# Altium schematic sheet POWER.SchDoc
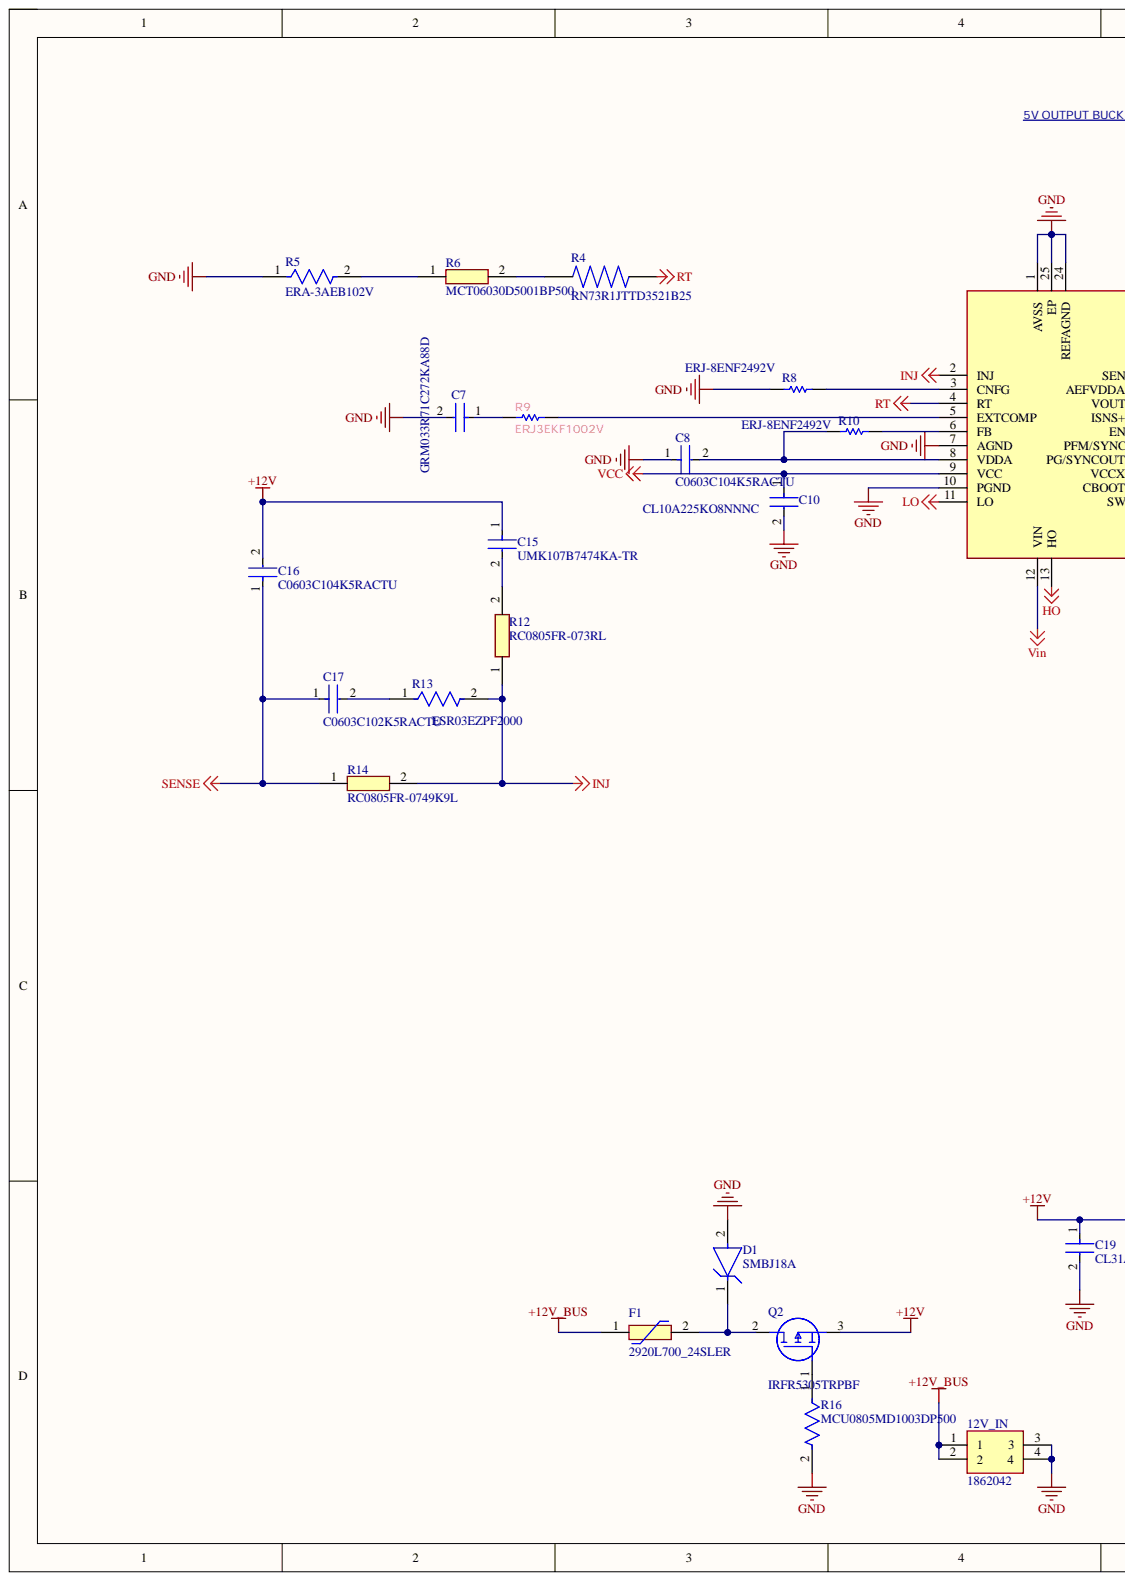
[diagram p0: POWER.SchDoc, left]
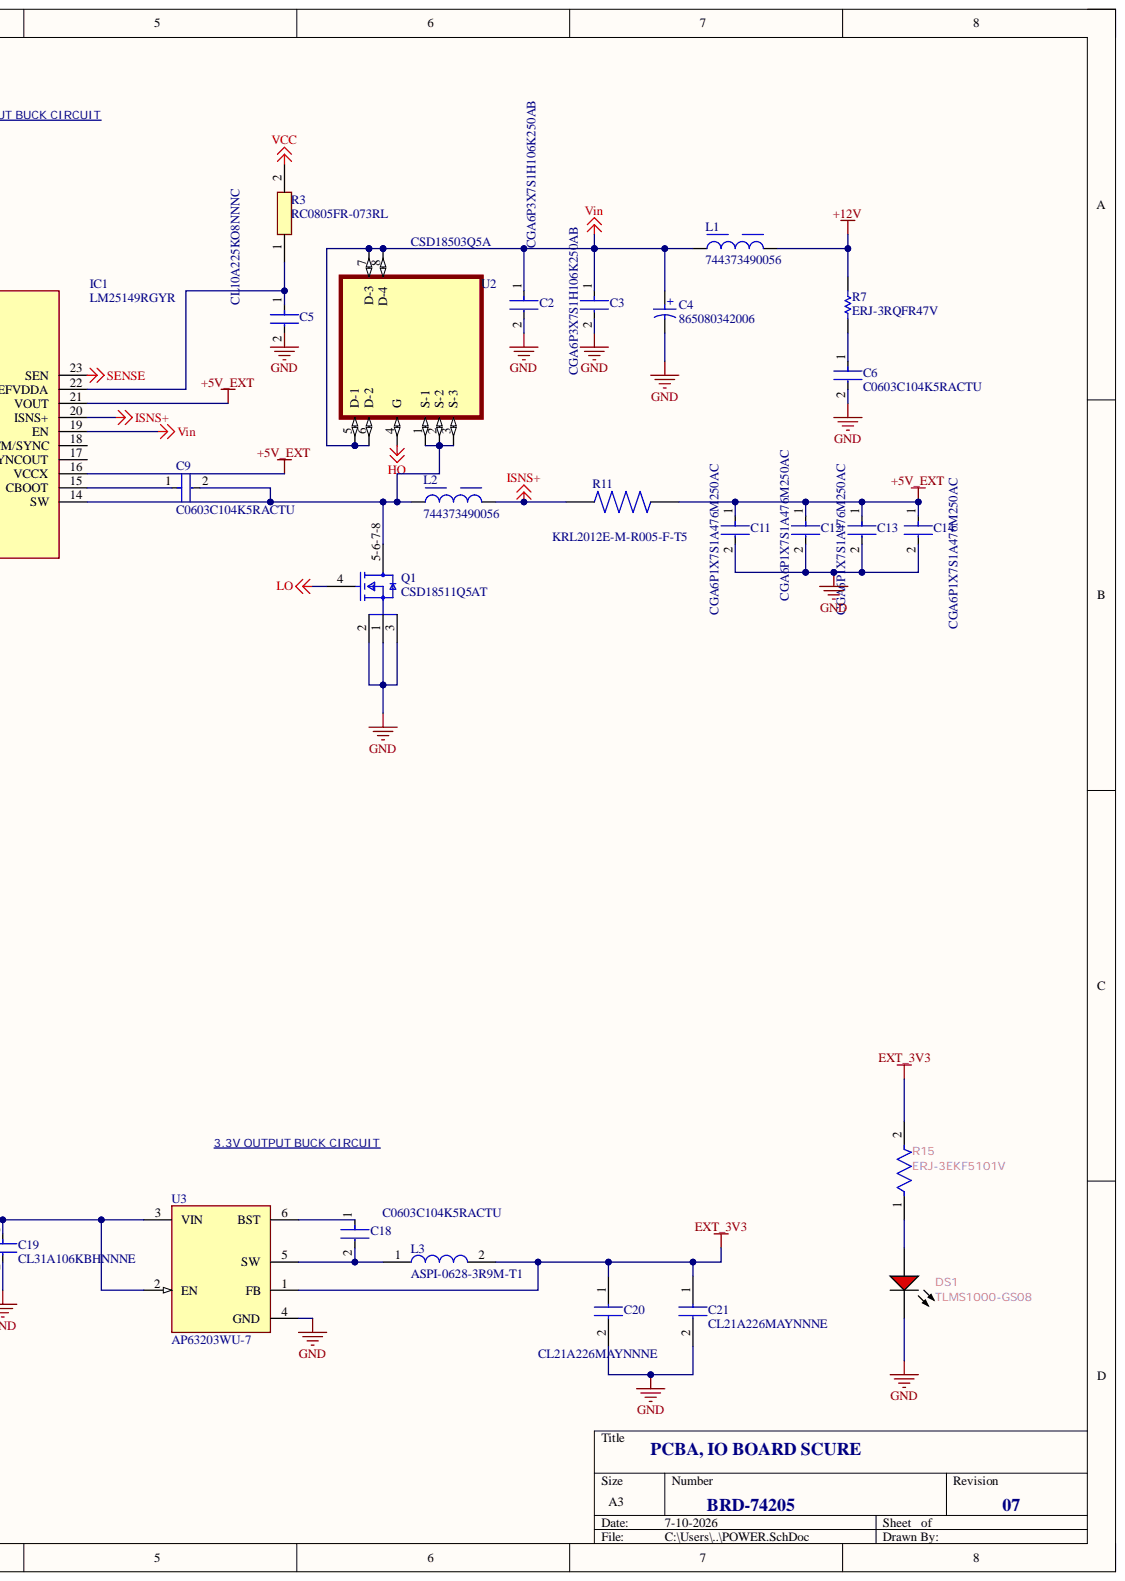
[diagram p1: POWER.SchDoc, right]

## Parts
12V_IN  1862042  [1862042]
C2  CGA6P3X7S1H106K250AB  [FP-CGA6P-IPC_A]
C3  CGA6P3X7S1H106K250AB  [FP-CGA6P-IPC_A]
C4  865080342006  [WCAP-ASLI_D5H5.5]
C5  CL10A225KO8NNNC  [FP-CL10-IPC_A]
C6  C0603C104K5RACTU  [FP-C0603C-CF-MFG]
C7  GRM033R71C272KA88D  [FP-GRM033-0_03-IPC_A]
C8  C0603C104K5RACTU  [FP-C0603C-CF-MFG]
C9  C0603C104K5RACTU  [FP-C0603C-CF-MFG]
C10  CL10A225KO8NNNC  [FP-CL10-IPC_A]
C11  CGA6P1X7S1A476M250AC  [FP-CGA6P-MFG]
C12  CGA6P1X7S1A476M250AC  [FP-CGA6P-MFG]
C13  CGA6P1X7S1A476M250AC  [FP-CGA6P-MFG]
C14  CGA6P1X7S1A476M250AC  [FP-CGA6P-MFG]
C15  UMK107B7474KA-TR  [FP-0603-L_1_6_0_1-W_0_8_0-IPC_A]
C16  C0603C104K5RACTU  [FP-C0603C-CF-MFG]
C17  C0603C102K5RACTU  [FP-C0603C-CF-MFG]
C18  C0603C104K5RACTU  [FP-C0603C-CF-MFG]
C19  CL31A106KBHNNNE  [FP-CL31-IPC_C]
C20  CL21A226MAYNNNE  [CAPC2012X145N]
C21  CL21A226MAYNNNE  [CAPC2012X145N]
D1  SMBJ18A  [FP-SMBJ-MFG]
DS1  TLMS1000-GS08  [VISH-TLMS1000_V]
F1  2920L700_24SLER  [2920L700SLPR]
IC1  LM25149RGYR  [LM25149RGYR]
L1  744373490056  [WE-LHMI_7050]
L2  744373490056  [WE-LHMI_7050]
L3  ASPI-0628-3R9M-T1  [ASPI0628150MT1]
Q1  CSD18511Q5AT  [FP-DQJ0008A-MFG]
Q2  IRFR5305TRPBF  [IRFR5305TRPBF]
R3  RC0805FR-073RL  [RESC2012X60N]
R4  RN73R1JTTD3521B25  [RESC1608X55N]
R5  ERA-3AEB102V  [FP-ERA3A-IPC_A]
R6  MCT06030D5001BP500  [RESC1608X55N]
R7  ERJ-3RQFR47V  [RESC1608X55X30ML15T15]
R8  ERJ-8ENF2492V  [RESC3216X70X50LL05T20]
R9  ERJ3EKF1002V  [RESC1609X55X30LL15T15]
R10  ERJ-8ENF2492V  [RESC3216X70X50LL05T20]
R11  KRL2012E-M-R005-F-T5  [RESC1220X70N]
R12  RC0805FR-073RL  [RESC2012X60N]
R13  ESR03EZPF2000  [FP-ESR03-MFG]
R14  RC0805FR-0749K9L  [RESC2012X60N]
R15  ERJ-3EKF5101V  [FP-ERJ3EK-MFG]
R16  MCU0805MD1003DP500  [FP-MCU0805-IPC_C]
U2  CSD18503Q5A  [MOSFET_CSD19531Q5A]
U3  AP63203WU-7  [FP-TSOT26-MFG]

## Nets
+5V_EXT: C11.1 C12.1 C13.1 C14.1 IC1.16(VCCX) IC1.21(VOUT) R11.2
+12V: C15.1 C16.2 C19.1 L1.2 Q2.3 R7.2 U3.2(EN) U3.3(VIN)
+12V_BUS: 12V_IN.1 12V_IN.2 F1.1
EXT_3V3: C20.1 C21.1 L3.2 R15.2 U3.1(FB)
GND: 12V_IN.3 12V_IN.4 C2.2 C3.2 C4.2 C5.2 C6.2 C7.2 C8.1 C10.2 C11.2 C12.2 C13.2 C14.2 C19.2 C20.2 C21.2 D1.2 DS1.2 IC1.1(AVSS) IC1.7(AGND) IC1.10(PGND) IC1.24(REFAGND) IC1.25(EP) Q1.1 Q1.2 Q1.3 R5.1 R8.1 R16.2 U3.4(GND)
HO: IC1.13(HO) U2.4(G)
INJ: IC1.2(INJ) R12.1 R13.2 R14.2
ISNS+: IC1.20(ISNS+) L2.2 R11.1
LO: IC1.11(LO) Q1.4
RT: IC1.4(RT) R4.2
SENSE: C16.1 C17.1 IC1.23(SEN) R14.1
VCC: C10.1 IC1.9(VCC) R3.2
Vin: C2.1 C3.1 C4.1 IC1.12(VIN) IC1.19(EN) L1.1 U2.5(D-1) U2.6(D-2) U2.7(D-3) U2.8(D-4)
NetC5_1: C5.1 IC1.22(AEFVDDA) R3.1
NetC6_1: C6.1 R7.1
NetC7_1: C7.1 R9.1
NetC8_2: C8.2 IC1.8(VDDA) R10.1
NetC9_1: C9.1 IC1.15(CBOOT)
NetC9_2: C9.2 IC1.14(SW) L2.1 Q1.5-6-7-8 U2.1(S-1) U2.2(S-2) U2.3(S-3)
NetC15_2: C15.2 R12.2
NetC17_2: C17.2 R13.1
NetC18_1: C18.1 U3.6(BST)
NetC18_2: C18.2 L3.1 U3.5(SW)
NetD1_1: D1.1 F1.2 Q2.2
NetDS1_1: DS1.1 R15.1
NetIC1_3: IC1.3(CNFG) R8.2
NetIC1_5: IC1.5(EXTCOMP) R9.2
NetIC1_6: IC1.6(FB) R10.2
NetQ2_1: Q2.1 R16.1
NetR4_1: R4.1 R6.2
NetR5_2: R5.2 R6.1

Not connected: IC1 17(PG/SYNCOUT) 18(PFM/SYNC)

## Library data of the parts
12V_IN = 1862042: Connector; Manufacturer_Name: Phoenix Contact; Arrow Part Number: 1862042; Arrow Price/Stock: https://www.arrow.com/en/products/1862042/phoenix-contact?region=nac; Component Kind: Standard; Datasheet Link: https://www.mouser.co.uk/datasheet/2/324/3/1862042-1135906.pdf; Height: 10.2mm; Library Name: SamacSys.SchLib; Manufacturer_Part_Number: 1862042; Mouser Part Number: 651-1862042; Mouser Price/Stock: https://www.mouser.co.uk/ProductDetail/Phoenix-Contact/1862042?qs=tlR8i4ZVbUf%252B18zgC0QDGg%3D%3D
C2 C3 = CMP-2000-07444-2: Cap Ceramic 10uF 50V X7S 10% Pad SMD 1210 +125°C Automotive T/R; Capacitance: 10uF; Case Code (Imperial): 1210; Case Code (Metric): 3225; Case/Package: 1210; Component Kind: Standard; Composition: Ceramic; Depth: 2.5mm; Dielectric: X7S; Height: 2.5mm; Lead Free: Lead Free; Length: 3.2mm; Library Name: Altium Content Vault; Max Operating Temperature: 125°C; Min Operating Temperature: -55°C; Mount: Surface Mount; Package Quantity: 1; Packaging: Tape and Reel; Ratings: AEC-Q200; REACH SVHC: No SVHC; Termination: SMD/SMT; Thickness: 0.11inch; Tolerance: 10%; Voltage: 50V; Voltage Rating: 50V; Voltage Rating (DC): 50V; Weight: 0.000564oz; Width: 0.098inch
C4 = CMP-03913-53914570-1: 865080342006 WCAP-ASLI Aluminum Electrolytic Capacitors; Capacitance: 47µF; ESR (Equivalent Series Resistance): 832.685mΩ; Impedance: 1.05Ω; Insulation Resistance: 2.12766MΩ; Leakage Current: 7.52µA; Life (Hours): 2000hours; Polarity: Polar; Ripple Current: 195mA; Tolerance: 20%; Voltage Rating: 16V; Case/Package: Radial; Component Kind: Standard; Diameter: 5mm; Height: 5.5mm; Height - Seated (Max): 5.5118mm; Length: 5.5mm; Library Name: Altium Content Vault; Max Operating Temperature: 105°C; Min Operating Temperature: -55°C; Mount: Surface Mount; NexarPartId: 53914570; Packaging: Tape & Reel (TR); REACH SVHC: Unknown; Ripple Current (AC): 195mA; RoHS: Compliant; Voltage Rating (DC): 16V; Width: 5.3mm
C5 C10 = CMP-13271-000835-1: Cap Ceramic 2.2uF 16V X5R ±10% Pad SMD 0603 +85°C T/R; Capacitance: 2.2uF; Case Code (Imperial): 0603; Case Code (Metric): 1608; Case/Package: 0603; Component Kind: Standard; Contact Plating: Tin; Depth: 0.8mm; Dielectric: X5R; Height: 0.8mm; Lead Free: Lead Free; Length: 1.6mm; Library Name: Altium Content Vault; Material: Ceramic; Max Operating Temperature: 85°C; Min Operating Temperature: -55°C; Mount: Surface Mount; Package Quantity: 4000; Packaging: Tape and Reel; Radiation Hardening: No; Thickness: 0.035inch; Tolerance: 10%; Voltage: 16V; Voltage Rating: 16V; Voltage Rating (DC): 16V; Width: 0.031inch
C6 C8 C9 C16 C18 = CMP-2006-03061-2: CAP CER 0.1UF 50V X7R 0603; Manufacturer: KEMET; Automotive Grade: Grade 1; Capacitance: 0.10 μF; Material: Ceramic; Temperature Coefficient: 30ppm/°C; Tolerance: 0.1; Value: 0.10 μF; Automotive: Yes; Category: Cap; Component Kind: Standard; ComponentLink2Description: Datasheet; ComponentLink2URL: https://api.kemet.com/component-edge/download/datasheet/C0603C100J5GACAUTO.pdf; Device Class L1: Passive Components; Device Class L2: Capacitors; Device Class L3: Ceramic Capacitors; Digikey Description: CAP CER 0.1UF 50V X7R 0603; Height: 0.87mm; Lead Free: Yes; Library Name: Altium Content Vault; Manufacturer Part Number: C0603C104K5RACTU; Octopart: https://octopart.com/c0603c104k5ractu-kemet-139244; Package: C0603C-CF; RoHS: TRUE; Temperature: -55°C to +125°C; Temperature Characteristic: X7R; Temperature Range High: +125°C; Temperature Range Low: -55°C; Voltage Rating: 50 V
C7 = CMP-2010-01696-2: Chip Multilayer Ceramic Capacitors for General Purpose, 0201, 2700pF, X7R, 15%, 10%, 16V; Capacitance: 2.7nF; Case Code (Imperial): 0201; Case Code (Metric): 0603; Case/Package: 0201; Component Kind: Standard; Depth: 0.3mm; Dielectric: X7R; Height: 0.3mm; Length: 0.6mm; Library Name: Altium Content Vault; Max Operating Temperature: 125°C; Min Operating Temperature: -55°C; Mount: Surface Mount; Package Quantity: 15000; Packaging: Tape and Reel; Radiation Hardening: No; Termination: SMD/SMT; Thickness: 0.013inch; Tolerance: 10%; Voltage Rating: 16V; Voltage Rating (DC): 16V; Width: 0.3mm
C11-C14 = CMP-08246-001520-1: AUTOMOTIVE GRADE,1210,X7S,10V,47; Component Kind: Standard; Library Name: Altium Content Vault
C15 = CMP-14477-000135-3: Ceramic Capacitor General Use 0.47uF ±10% 50V X7R 0603; NexarPartId: 22313259; Capacitance: 470nF; Case Code (Imperial): 0603; Case Code (Metric): 1608; Case/Package: 0603; Component Kind: Standard; Depth: 800µm; Dielectric: X7R; Halogen Free: Halogen Free; Height: 900µm; Lead Free: Lead Free; Length: 1.6mm; Library Name: Altium Content Vault; Material: Ceramic; Max Length: 1.7mm; Max Operating Temperature: 125°C; Max Thickness: 900µm; Max Width: 900µm; Min Length: 1.5mm; Min Operating Temperature: -55°C; Min Thickness: 700µm; Min Width: 700µm; Mount: Surface Mount; Packaging: Digi-Reel®; Radiation Hardening: No; RoHS: Compliant; Schedule B: 8532240020; Simulation: UMK107B7474_A-TR; Termination: SMD/SMT; Thickness: 889µm; Tolerance: 10%; Voltage: 50V; Voltage Rating: 50V; Voltage Rating (DC): 50V; Width: 787.4µm
C17 = CMP-2006-03022-3: CAP CER 1000PF 50V X7R 0603; Manufacturer: KEMET; Automotive Grade: Grade 1; Capacitance: 1,000 pF; Material: Ceramic; Temperature Coefficient: 30ppm/°C; Tolerance: 0.1; Value: 1,000 pF; Automotive: Yes; Category: Cap; Component Kind: Standard; ComponentLink2Description: Datasheet; ComponentLink2URL: https://api.kemet.com/component-edge/download/datasheet/C0603C100J5GACAUTO.pdf; Device Class L1: Passive Components; Device Class L2: Capacitors; Device Class L3: Ceramic Capacitors; Digikey Description: CAP CER 1000PF 50V X7R 0603; Height: 0.87mm; Lead Free: Yes; Library Name: Altium Content Vault; Manufacturer Part Number: C0603C102K5RACTU; Mouser Description: Capacitor; Ceramic; Cap; 1000pF; Tol 10%; SMT; Vol-Rtg 50V; X7R; Tape and Reel; Octopart: https://octopart.com/c0603c102k5ractu-kemet-144878; Package: C0603C-CF; RoHS: TRUE; Temperature: -55°C to +125°C; Temperature Characteristic: X7R; Temperature Range High: +125°C; Temperature Range Low: -55°C; Voltage Rating: 50 V
C19 = CMP-13271-000016-1: CAP CER 10UF 50V X5R 1206; Manufacturer: Samsung; Material: Ceramic; Temperature Coefficient: 15%; Tolerance: 10%; Automotive: No; Capacitance: 10uF; Category: Cap; Component Kind: Standard; ComponentLink2Description: Datasheet; ComponentLink2URL: http://www.samsungsem.com/kr/support/product-search/mlcc/__icsFiles/afieldfile/2018/06/21/CL31A106KBHNNNE.pdf; Device Class L1: Passive Components; Device Class L2: Capacitors; Device Class L3: Ceramic Capacitors; Digikey Description: CAP CER 10UF 50V X5R 1206; Height: 1.8mm; Ipc Land Pattern Name: CAPC320160X160; Lead Free: Yes; Library Name: Altium Content Vault; Manufacturer Part Number: CL31A106KBHNNNE; Octopart: https://octopart.com/cl31a106kbhnnne-samsung-19832778; Package: CL31; RoHS: TRUE; Temperature: -55°C to +85°C; Temperature Characteristic: X5R; Temperature Range High: +85°C; Temperature Range Low: -55°C; Value: 10uF; Voltage: 50V; Voltage Rating: 50V
C20 C21 = CL21A226MAYNNNE: Capacitor; Manufacturer_Name: SAMSUNG; Arrow Part Number: CL21A226MAYNNNE; Arrow Price/Stock: https://www.arrow.com/en/products/cl21a226maynnne/samsung-electro-mechanics?utm_currency=USD&region=europe; Component Kind: Standard; Datasheet Link: http://product.samsungsem.com/mlcc/CL21A226MAYNNN.do; Height: 1.45mm; Library Name: SamacSys.SchLib; Manufacturer_Part_Number: CL21A226MAYNNNE; Mouser Part Number: 187-CL21A226MAYNNNE; Mouser Price/Stock: https://www.mouser.co.uk/ProductDetail/Samsung-Electro-Mechanics/CL21A226MAYNNNE?qs=xZ%2FP%252Ba9zWqY%2FVvCfXjMhqg%3D%3D
D1 = CMP-08607-000024-1: TVS DIODE 18V 29.2V DO214AA; Manufacturer: Littelfuse Inc; Breakdown Voltage: 20V; Direction: Unidirectional; Max Junction Temp: +150°C; Number Of Channels: 1; Peak Pulse Current: 20.6A; Peak Pulse Power: 600W; Automotive: No; Category: Diode; Clamping Voltage: 29.2V; Component Kind: Standard; ComponentLink2Description: Datasheet; ComponentLink2URL: https://www.littelfuse.com/~/media/electronics/datasheets/tvs_diodes/littelfuse_tvs_diode_smbj_datasheet.pdf.pdf; Device Class L1: Circuit Protection; Device Class L2: TVS Diodes; Device Class L3: unset; Digikey Description: TVS DIODE 18V 29.2V DO214AA; Height: 2.61mm; Lead Free: Yes; Library Name: Altium Content Vault; Manufacturer Part Number: SMBJ18A; Mouser Description: TVS Diodes - Transient Voltage Suppressors 18Vr 600W 20.6A 5% UniDirectional; Octopart: https://octopart.com/smbj18a-littelfuse-35303; Package: SMBJ; Reverse Standoff Voltage: 18V; RoHS: TRUE; Temperature: -65°C to +150°C; Temperature Range High: +150°C; Temperature Range Low: -65°C
DS1 = CMP-2000-07254-1: Low Current LED, 40 mW, 1.8 V, -40 to 100 degC, 2-Pin SMD (0603), RoHS, Tape and Reel; Manufacturer: Vishay; Automotive Qualification Standard: AEC-Q100; Bulb Size: 1.6mmx0.8mm; Forward Current If: 2mA; Forward Voltage: 1.8V; LED Colour: Red; Lens Shape: Rectangular; Luminous Intensity: 4mcd; SVHC: ToBeAdvised; Viewing Angle: 80°; Wavelength Typ: 636nm; Component Kind: Standard; Datasheet URL: https://datasheet.ciiva.com/5798/1698881-5798337.pdf; Datasheet Version: Rev. 1.7, 02/2011; LED Mounting: SMD; Library Name: Altium Content Vault; Manufacturer URL: http://www.vishay.com/; Mounting Technology: SurfaceMount; MSL: MSL2-1year; Package Description: 2-Pin Surface Mount Device, Body 1.6 x 0.8 mm; Package Reference: TLMS1000; Packaging: CutTape; Product Range: -
F1 = 2920L700_24SLER: Resettable Fuse; Manufacturer_Name: LITTELFUSE; Manufacturer_Part_Number: 2920L700/24SLER; Arrow Part Number: 2920L700/24SLER; Arrow Price/Stock: null?region=nac; Component Kind: Standard; Datasheet Link: https://www.mouser.fr/datasheet/2/240/littelfuse_ptc_low_rho_datasheet_pdf-1901322.pdf; Height: 1.2mm; Mouser Part Number: 576-2920L700/24SLER; Mouser Price/Stock: https://www.mouser.co.uk/ProductDetail/Littelfuse/2920L700-24SLER?qs=7MVldsJ5UazQEVth1QGslQ%3D%3D
IC1 = LM25149RGYR: Integrated Circuit; Manufacturer_Name: Texas Instruments; Component Kind: Standard; Datasheet Link: https://www.ti.com/lit/gpn/lm25149; Height: 1mm; Library Name: SamacSys.SchLib; Manufacturer_Part_Number: LM25149RGYR; Mouser Part Number: 595-LM25149RGYR; Mouser Price/Stock: https://www.mouser.co.uk/ProductDetail/Texas-Instruments/LM25149RGYR?qs=doiCPypUmgFlA8bcUKioXg%3D%3D
L1 L2 = CMP-1353-00073-6: NexarPartId: 21272430; Core Material: Composite, Ferrite; Current Rating: 13A; DC Resistance (DCR): 3.6mΩ; Inductance: 560nH; Inductance Tolerance: 20%; Saturation Current: 19.9A; Self Resonant Frequency: 85MHz; Series Resistance: 4.2MΩ; Shielding: Shielded; Test Frequency: 100kHz; Ambient Temperature Range High: 85°C; Ambient Temperature Range Low: -40°C; Case/Package: Nonstandard; Component Kind: Standard; Composition: Wirewound; Depth: 6.6mm; Height: 4.8mm; Height - Seated (Max): 5.0038mm; Length: 7.3mm; Library Name: Altium Content Vault; Max DC Current: 13A; Max Operating Temperature: 125°C; Min Operating Temperature: -40°C; Mount: Surface Mount; Packaging: Digi-Reel®; REACH SVHC: Unknown; RMS Current (Irms): 13A; RoHS: Compliant; Termination: SMD/SMT; Tolerance: 20%; Width: 6.6mm
L3 = ASPI-0628-3R9M-T1: Inductor; Manufacturer_Name: ABRACON; Arrow Part Number: ASPI-0628-3R9M-T1; Arrow Price/Stock: https://www.arrow.com/en/products/aspi-0628-3r9m-t1/abracon?region=europe; Component Kind: Standard; Datasheet Link: https://abracon.com/Magnetics/new/ASPI-0628.pdf; Height: 2.8mm; Library Name: SamacSys.SchLib; Manufacturer_Part_Number: ASPI-0628-3R9M-T1; Mouser Part Number: 815-ASPI-0628-3R9MT1; Mouser Price/Stock: https://www.mouser.co.uk/ProductDetail/ABRACON/ASPI-0628-3R9M-T1?qs=7Oetya%252B4D2Cz7OuGoDTYww%3D%3D
Q1 = CMP-04962-000088-1: MOSFET N-CH 40V 159A 8VSON; Element Configuration: Single; Input Capacitance: 5.85nF; Max Power Dissipation: 104W; Rds On Max: 3.5mR; Component Kind: Standard; Continuous Drain Current (ID): 159A; Drain to Source Voltage (Vdss): 40V; Library Name: Altium Content Vault; Max Operating Temperature: 150°C; Min Operating Temperature: -55°C; Mount: Surface Mount; Number of Pins: 8; Packaging: Tape and Reel; RoHS Compliant: Yes
Q2 = IRFR5305TRPBF: MOSFET (P-Channel); Manufacturer_Name: Infineon; Arrow Part Number: IRFR5305TRPBF; Arrow Price/Stock: https://www.arrow.com/en/products/irfr5305trpbf/infineon-technologies-ag?utm_currency=USD&region=europe; Component Kind: Standard; Datasheet Link: https://www.infineon.com/dgdl/irfr5305pbf.pdf?fileId=5546d462533600a401535632522820ff; Height: 2.507mm; Manufacturer_Part_Number: IRFR5305TRPBF; Mouser Part Number: 942-IRFR5305TRPBF; Mouser Price/Stock: https://www.mouser.co.uk/ProductDetail/Infineon-Technologies/IRFR5305TRPBF?qs=NFlznGuI7112KuIDPbxMDg%3D%3D
R3 R12 = RC0805FR-073RL: Resistor; Manufacturer_Name: KEMET; Arrow Part Number: RC0805FR-073RL; Arrow Price/Stock: https://www.arrow.com/en/products/rc0805fr-073rl/yageo?region=nac; Component Kind: Standard; Datasheet Link: http://www.yageo.com/documents/recent/PYu-RC_Group_51_RoHS_L_10.pdf; Height: 0.6mm; Manufacturer_Part_Number: RC0805FR-073RL; Mouser Part Number: 603-RC0805FR-073RL; Mouser Price/Stock: https://www.mouser.co.uk/ProductDetail/YAGEO/RC0805FR-073RL?qs=g6xwsc4j%252B%2FqwNwBJ9ElXyA%3D%3D
  R12: Library Name: SamacSys.SchLib
R5 = CMP-2000-00873-2: RES SMD 1K OHM 0.1% 1/10W 0603; Max Power Dissipation: 100mW; Resistance: 1kR; Temperature Coefficient: 25ppm/°C; Voltage Rating: 75V; Case Code (Imperial): 0603; Case Code (Metric): 1608; Case/Package: 0603; Component Kind: Standard; Construction: Rectangular; Contact Plating: Tin; Depth: 0.8mm; Height: 0.45mm; Length: 1.6mm; Library Name: Altium Content Vault; Max Operating Temperature: 155°C; Military Standard: Not; Min Operating Temperature: -55°C; Mount: Surface Mount; Number of Pins: 2; Number of Terminations: 2; Package Quantity: 5000; Packaging: Tape and Reel; Power Rating: 100mW; Radiation Hardening: No; REACH SVHC: No SVHC; Resistance Tolerance: 0.1%; Resistor Type: Thin Film; RoHS Compliant: Yes; Series: ERA-3A; Size Code: 0603; Technology: METAL FILM; Termination: SMD/SMT; Tolerance: 0.1%; Width: 0.8mm
R6 = MCT06030D5001BP500: Resistor; Manufacturer_Name: Vishay; Component Kind: Standard; Datasheet Link: http://www.vishay.com/docs/28705/mcx0x0xpro.pdf; Height: 0.55mm; Manufacturer_Part_Number: MCT06030D5001BP500
R7 = CMP-2000-00857-1: Manufacturer: Panasonic; Component Kind: Standard; Library Name: Altium Content Vault; Part Number: ERJ-3RQFR47V
R8 R10 = CMP-2003-00246-1: Manufacturer: Panasonic; Component Kind: Standard; Library Name: Altium Content Vault; Part Number: ERJ-8ENF2492V
R9 = CMP-1012-00623-3: Chip Resistor, 10 KOhm, +/- 1%, 0.1 W, -55 to 155 degC, 0603 (1608 Metric), RoHS, Tape and Reel; Manufacturer: Panasonic; Case\/Package: 0603; Max Power Dissipation: 100mW; Resistance: 10KOhm; Temperature Coefficient: 100ppm\/degreesC; Voltage Rating: 75V; Case Code (Imperial): 0603; Case Code (Metric): 1608; Component Kind: Standard; Composition: ThickFilm; Contact Plating: Tin; Datasheet URL: https://industrial.panasonic.com/cdbs/www-data/pdf/RDA0000/AOA0000C304.pdf; Datasheet Version: 02/2017; Depth: 0.8mm; Height: 0.45mm; Lead Free: LeadFree; Length: 1.6mm; Library Name: Altium Content Vault; Manufacturer URL: http://www.panasonic.com/; Max Operating Temperature: 155degC; Military Standard: Not; Min Operating Temperature: -55degC; Mount: SurfaceMount; Mounting Technology: SurfaceMount; Number of Pins: 2; Number of Terminations: 2; Package Description: 2-Pin Surface Mount Device, Body 1.6 x 0.85 mm; Package Quantity: 1; Package Reference: 603; Packaging: TapeandReel; Power Rating: 100mW; Radiation Hardening: No; REACH SVHC: NoSVHC; RoHSCompliant: true; Termination: SMD/SMT; Tolerance: 1%; Voltage Rating (DC): 75V; Width: 0.031inch
R11 = KRL2012E-M-R005-F-T5: 5 mOhms Â±1% 1W Chip Resistor Wide 0805 (2012 Metric), 0508 Automotive AEC-Q200, Current Sense Metal Foil; MF: Susumu; Check_prices: https://www.snapeda.com/parts/KRL2012E-M-R005-F-T5/Susumu/view-part/?ref=eda; Component Kind: Standard; Library Name: KRL2012E-M-R005-F-T5.IntLib; MP: KRL2012E-M-R005-F-T5; Package: 2012-2 Susumu; SnapEDA_Link: https://www.snapeda.com/parts/KRL2012E-M-R005-F-T5/Susumu/view-part/?ref=snap
R13 = CMP-08839-001459-1: 200Ω ±1% 0.25W 0603 Anti-Surge Chip Resistor AEC-Q200; Max Power Dissipation: 250mW; Resistance: 200R; Temperature Coefficient: 100ppm/°C; Case Code (Imperial): 0603; Case Code (Metric): 1608; Case/Package: 0603; Component Kind: Standard; Features: Automotive AEC-Q200; Height: 0.022inch; Lead Free: Lead Free; Length: 0.063inch; Library Name: Altium Content Vault; Max Operating Temperature: 155°C; Min Operating Temperature: -55°C; Number of Terminations: 2; Package Quantity: 1; Packaging: Tape and Reel; Resistor Type: Thick Film; RoHS Compliant: Yes; Series: ESR; Tolerance: 1%; Width: 0.031inch
R14 = RC0805FR-0749K9L: Resistor; Manufacturer_Name: KEMET; Component Kind: Standard; Datasheet Link: http://www.yageo.com/documents/recent/PYu-RC_Group_51_RoHS_L_10.pdf; Height: 0.6mm; Library Name: SamacSys.SchLib; Manufacturer_Part_Number: RC0805FR-0749K9L; Mouser Part Number: 603-RC0805FR-0749K9L; Mouser Price/Stock: https://www.mouser.co.uk/ProductDetail/YAGEO/RC0805FR-0749K9L?qs=VLOqbHBAO5cDWH1fFfdblw%3D%3D
R15 = CMP-2000-00540-8: RES SMD 5.1K OHM 1% 1/10W 0603; Max Power Dissipation: 100mW; Resistance: 5.1kR; Temperature Coefficient: 100ppm/°C; Voltage Rating: 75V; Case Code (Imperial): 0603; Case Code (Metric): 1608; Case/Package: 0603; Component Kind: Standard; Construction: Rectangular; Contact Plating: Tin; Depth: 0.8mm; Features: Automotive AEC-Q200; Height: 0.022inch; Lead Free: Lead Free; Length: 1.6mm; Library Name: Altium Content Vault; Max Operating Temperature: 155°C; Military Standard: Not; Min Operating Temperature: -55°C; Mount: Surface Mount; Number of Terminations: 2; Package Quantity: 1; Packaging: Tape and Reel; Power Rating: 100mW; Radiation Hardening: No; Reach SVHC Compliant: Yes; Resistance Tolerance: 1%; Resistor Type: Thick Film; RoHS Compliant: Yes; Series: ERJ; Size Code: 0603; Termination: SMD/SMT; Tolerance: 1%; Voltage Rating (DC): 75V; Weight: 7.1E-05oz; Width: 0.8mm
R16 = CMP-02407-011860-1: RES 1.05K OHM 0.5% 1/5W 0805; Max Power Dissipation: 200mW; Resistance: 100kR; Temperature Coefficient: 25ppm/°C; Voltage Rating: 150V; Case Code (Imperial): 0805; Case Code (Metric): 2012; Case/Package: 0805; Component Kind: Standard; Features: Automotive AEC-Q200; Height: 0.024inch; Length: 0.079inch; Library Name: Altium Content Vault; Max Operating Temperature: 175°C; Min Operating Temperature: -55°C; Number of Terminations: 2; Package Quantity: 1; Packaging: Tape and Reel; Power Rating: 200mW; Resistor Type: Thin Film; RoHS Compliant: Yes; Series: MCU 0805 - Professional; Tolerance: 0.5%; Weight: 0.000162oz; Width: 1.25mm
U2 = CSD18503Q5A: 40-V, N channel NexFETâ„¢ power MOSFET, single SON 5 mm x 6 mm, 4.3 mOhm; MF: Texas Instruments; Availability: In Stock; Check_prices: https://www.snapeda.com/parts/CSD18503Q5A/Texas+Instruments/view-part/?ref=eda; Component Kind: Standard; Library Name: CSD18503Q5A.IntLib; MP: CSD18503Q5A; Package: VSONP-8 Texas Instruments; Price: None; Purchase-URL: https://pricing.snapeda.com/search/part/CSD18503Q5A/?ref=eda; SnapEDA_Link: https://www.snapeda.com/parts/CSD18503Q5A/Texas+Instruments/view-part/?ref=snap
U3 = CMP-12757-000006-1: IC REG BUCK 3.3V 2A TSOT26; Manufacturer: Diodes Inc.; Max Junction Temp: +160°C; Max Supply Voltage: 32V; Min Supply Voltage: 3.8V; Nominal Supply Current: 22uA; Number Of Outputs: 1; Output Type: Fixed; Standoff Height: 0.01mm; Switching Frequency: 1.1MHz; Ambient Temperature Range High: +85°C; Ambient Temperature Range Low: -40°C; Automotive: No; Category: IC; Component Kind: Standard; ComponentLink2Description: Datasheet; ComponentLink2URL: https://www.diodes.com/assets/Datasheets/AP63200-AP63201-AP63203-AP63205.pdf; Device Class L1: Integrated Circuits (ICs); Device Class L2: Power Management ICs; Device Class L3: Voltage Regulators - Switching; Digikey Description: IC REG BUCK 3.3V 2A TSOT26; Footprint Url: https://www.diodes.com/assets/Package-Files/TSOT26.pdf; Height: 1mm; Lead Free: Yes; Library Name: Altium Content Vault; Manufacturer Part Number: AP63203WU-7; Mouser Description: AP63203 Series 3.3 V 2 A SMT Fixed Low IQ Synchronous Buck - TSOT-26; Octopart: https://octopart.com/ap63203wu-7-diodes+inc.-98099550; Output Current: 2A; Output Voltage: 3.3V; Package: TSOT26; RoHS: TRUE; Switching Topology: Buck; Temperature: to +160°C; Temperature Range High: +160°C
R4 = RN73R1JTTD3521B25: Availability: Unavailable; Component Kind: Standard; Library Name: RN73R1JTTD3521B25.IntLib; MP: RN73R1JTTD3521B25; Package: None; Price: None
